# Altium schematic sheet Baro_Mag.SchDoc(Baro_Mag)
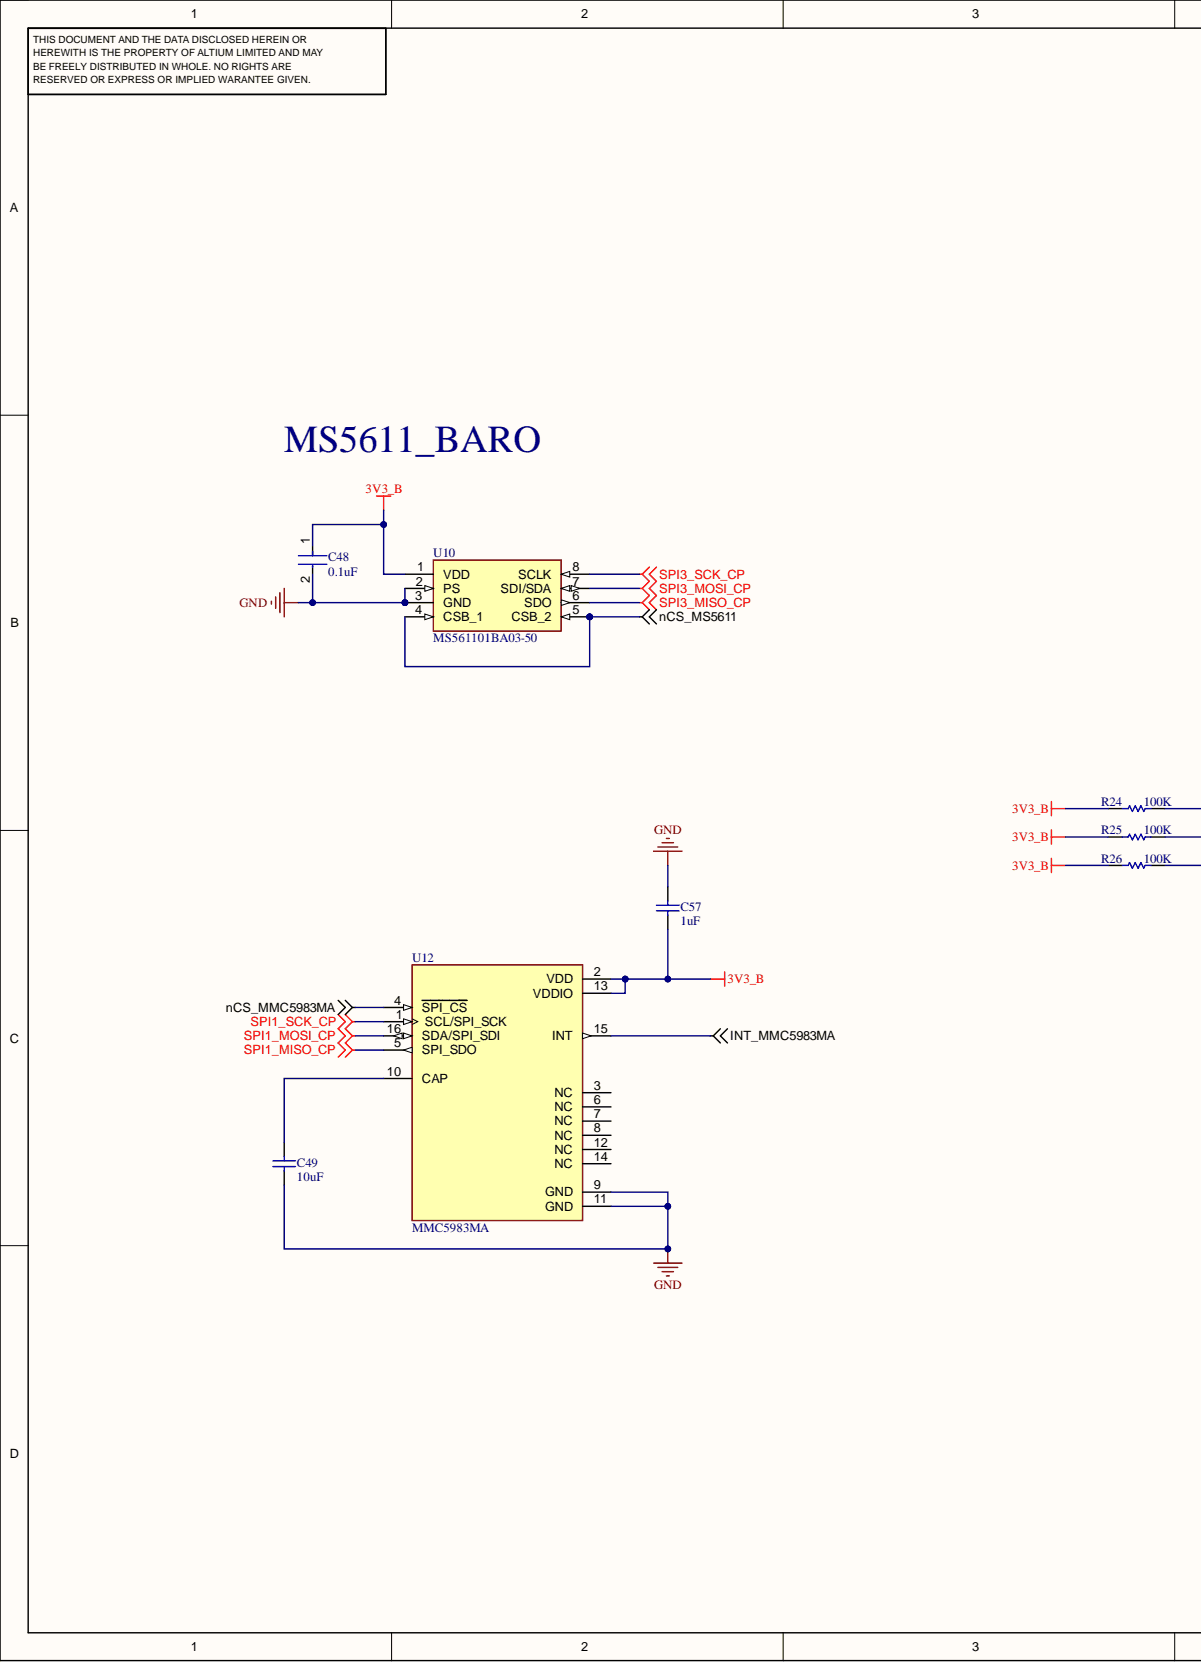
[diagram p0: Baro_Mag.SchDoc, left]
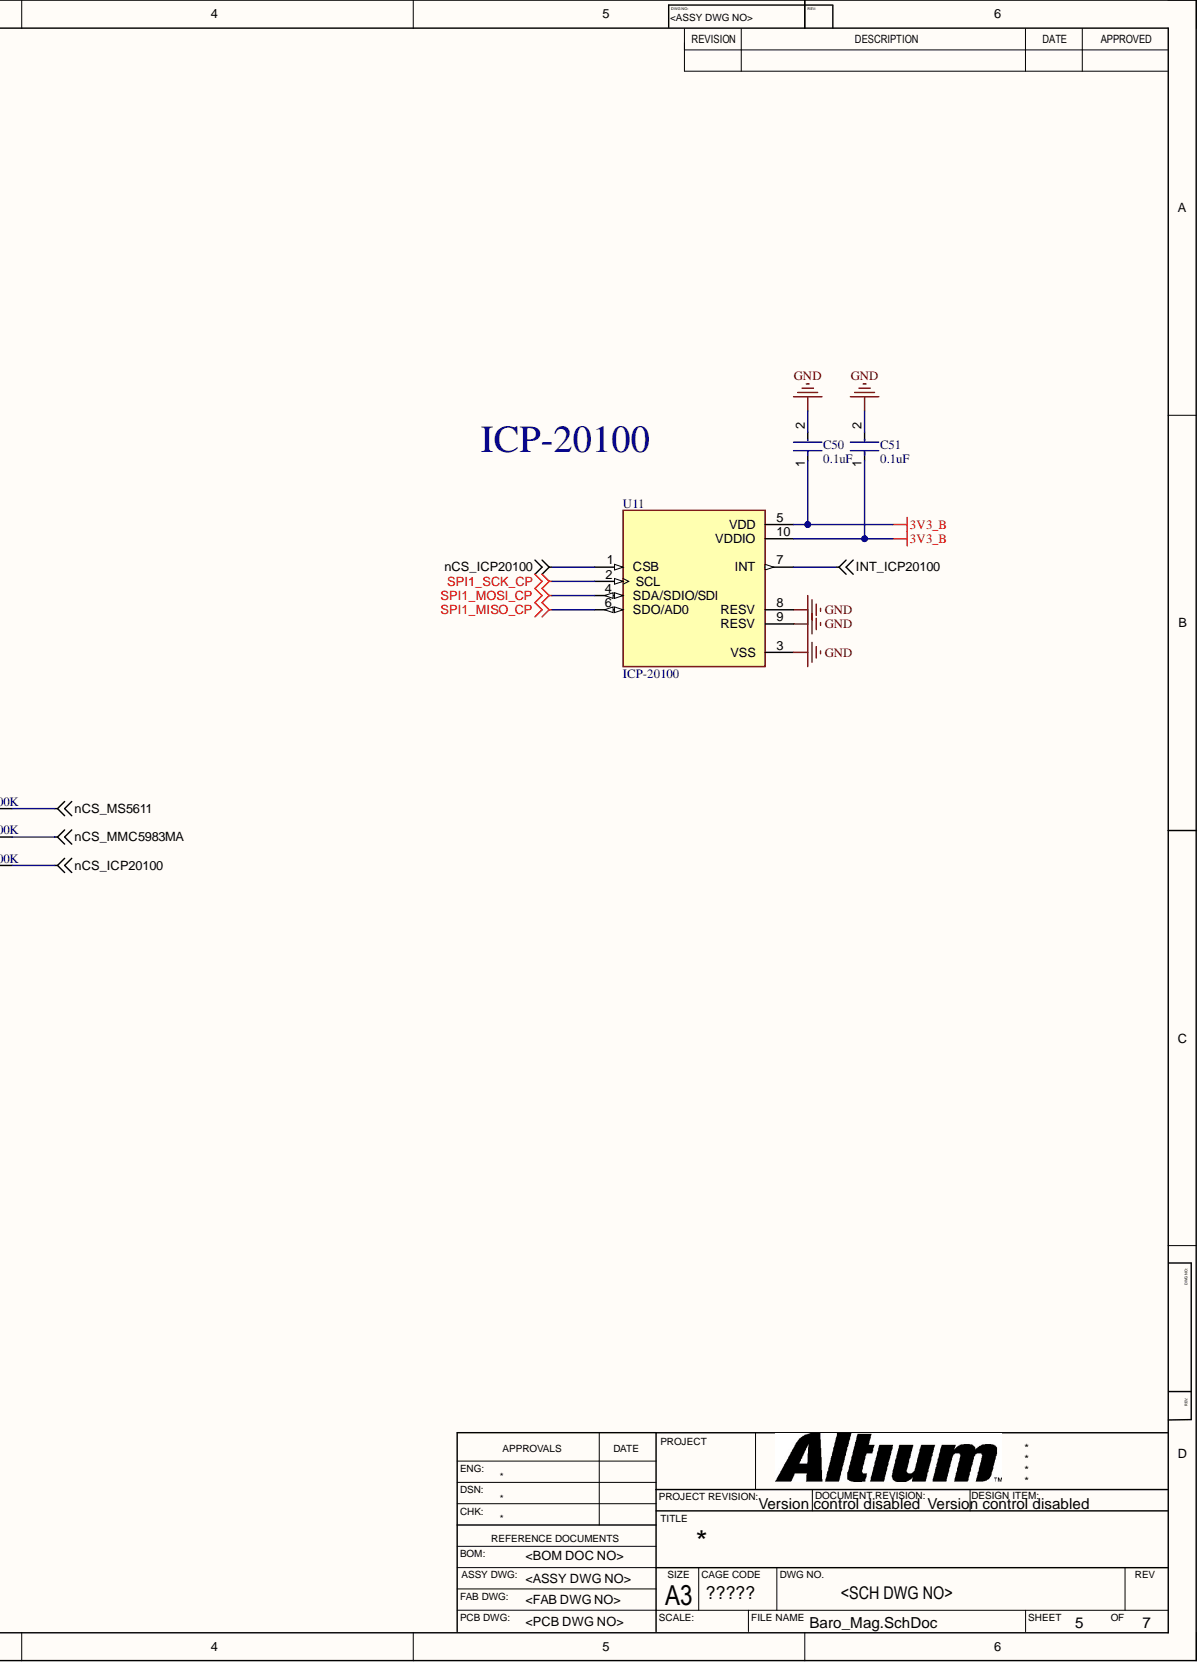
[diagram p1: Baro_Mag.SchDoc, right]

## Parts
C48  0.1uF
C49  10uF
C50  0.1uF
C51  0.1uF
C57  1uF
R24  100K
R25  100K
R26  100K
U10  MS561101BA03-50
U11  ICP-20100
U12  MMC5983MA

## Nets
3V3_B: C48.1 C50.1 C51.1 C57.1 R24.2 R25.2 R26.2 U10.1(VDD) U11.5(VDD) U11.10(VDDIO) U12.2(VDD) U12.13(VDDIO)
GND: C48.2 C49.2 C50.2 C51.2 C57.2 U10.2(PS) U10.3(GND) U11.3(VSS) U11.8(RESV) U11.9(RESV) U12.9(GND) U12.11(GND)
INT_ICP20100: U11.7(INT)
INT_MMC5983MA: U12.15(INT)
nCS_ICP20100: R26.1 U11.1(CSB)
nCS_MMC5983MA: R25.1 U12.4(SPI_CS)
nCS_MS5611: R24.1 U10.4(CSB_1) U10.5(CSB_2)
SPI1_MISO_CP: U11.6(SDO/AD0) U12.5(SPI_SDO)
SPI1_MOSI_CP: U11.4(SDA/SDIO/SDI) U12.16(SDA/SPI_SDI)
SPI1_SCK_CP: U11.2(SCL) U12.1(SCL/SPI_SCK)
SPI3_MISO_CP: U10.6(SDO)
SPI3_MOSI_CP: U10.7(SDI/SDA)
SPI3_SCK_CP: U10.8(SCLK)
NetC49_1: C49.1 U12.10(CAP)

Not connected: U12 3(NC) 6(NC) 7(NC) 8(NC) 12(NC) 14(NC)

## Library data of the parts
C48 C50 C51 = CMP-2006-03061-2: CAP CER 0.1UF 50V X7R 0603; Manufacturer: KEMET; Manufacturer Part Number: C0603C104K5RACTU; Automotive Grade: Grade 1; Capacitance: 0.10 μF; Material: Ceramic; Temperature Coefficient: 30ppm/°C; Tolerance: 0.1; Value: 0.10 μF; Automotive: Yes; Category: Cap; Component Kind: Standard; ComponentLink2Description: Datasheet; ComponentLink2URL: https://api.kemet.com/component-edge/download/datasheet/C0603C100J5GACAUTO.pdf; Device Class L1: Passive Components; Device Class L2: Capacitors; Device Class L3: Ceramic Capacitors; Digikey Description: CAP CER 0.1UF 50V X7R 0603; Height: 0.87mm; Lead Free: Yes; Library Name: Altium Content Vault; Octopart: https://octopart.com/c0603c104k5ractu-kemet-139244; Package: C0603C-CF; RoHS: TRUE; Temperature: -55°C to +125°C; Temperature Characteristic: X7R; Temperature Range High: +125°C; Temperature Range Low: -55°C; Voltage Rating: 50 V
C49 = CMP-2007-01044-1: Manufacturer: Kemet; Part Number: C0805C106K8RACTU; Component Kind: Standard; Library Name: Altium Content Vault
C57 = CMP-2006-02298-2: Manufacturer: Kemet; Part Number: C0603C105K4RACTU; Component Kind: Standard; Library Name: Altium Content Vault
R24-R26 = CMP-2000-01852-1: Manufacturer: KOA Speer; Part Number: RK73B1JTTD104J; Component Kind: Standard; Library Name: Altium Content Vault
U10 = MS561101BA03-50: Integrated Circuit; Manufacturer_Name: TE Connectivity; Arrow Part Number: MS561101BA03-50; Arrow Price/Stock: https://www.arrow.com/en/products/ms561101ba03-50/te-connectivity?utm_currency=USD&region=nac; Component Kind: Standard; Datasheet Link: https://www.te.com/commerce/DocumentDelivery/DDEController?Action=srchrtrv&DocNm=MS5611-01BA03&DocType=Data%20Sheet&DocLang=English&PartCntxt=MS561101BA03-50&DocFormat=pdf; Manufacturer_Part_Number: MS561101BA03-50; Mouser Part Number: 824-MS561101BA03-50; Mouser Price/Stock: https://www.mouser.co.uk/ProductDetail/Measurement-Specialties/MS561101BA03-50?qs=%252BgKeJhng5iV%252BnCz6Qd5iDw%3D%3D
U11 = CMP-010-00027-1: MF: TDK InvenSense; Availability: Not in stock; Case/Package: None; Check_prices: https://www.snapeda.com/parts/ICP-20100/TDK%20InvenSense/view-part/7331147/?ref=eda; Component Kind: Standard; Component Type: Standard; Design Item ID: ICP-20100; MP: ICP-20100; Pins: 10; Price: None; SnapEDA_Link: https://www.snapeda.com/parts/ICP-20100/TDK%20InvenSense/view-part/7331147/?ref=snap; Source: ICP-20100.SchLib; Library Name: Hitesh Bhoyar
U12 = CMP-051-000000-1: MMC5983 series Magnetic, Magnetometer Sensor Evaluation Board; MF: Memsic Inc.; Availability: In Stock; Check_prices: https://www.snapeda.com/parts/MMC5983MA/Memsic+Inc./view-part/?ref=eda; Component Kind: Standard; Component Type: Standard; Design Item ID: MMC5983MA; MP: MMC5983MA; Package: VFLGA-16 Memsic Inc.; Pin Count: 16; Price: None; Purchase-URL: https://pricing.snapeda.com/search/part/MMC5983MA/?ref=eda; SnapEDA_Link: https://www.snapeda.com/parts/MMC5983MA/Memsic+Inc./view-part/?ref=snap; Source: MMC5983MA.SchLib; Library Name: Hitesh Bhoyar
